# Altium schematic sheet S04_Microcontroller.SchDoc
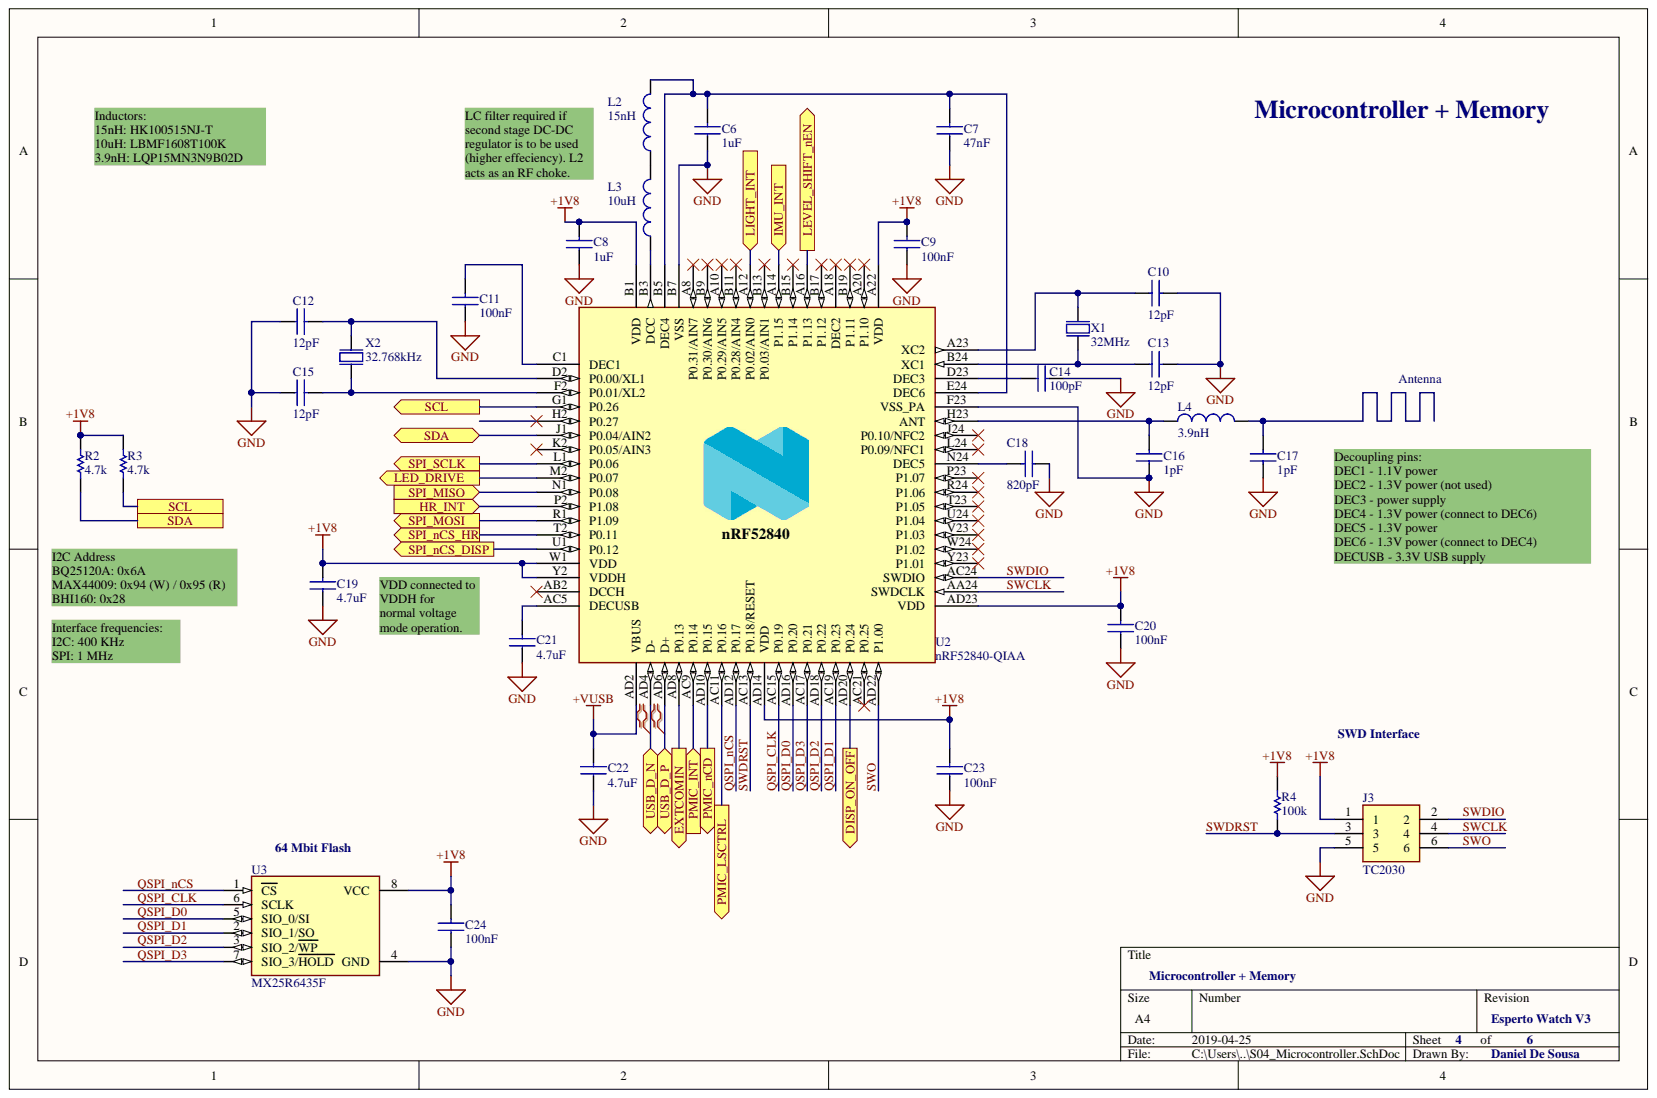
[diagram p0: S04_Microcontroller.SchDoc]

## Parts
C6  1uF  [CC_1005_0402_N]
C7  47nF  [CC_1005_0402_N]
C8  1uF  [CC_1005_0402_N]
C9  100nF  [CC_1005_0402_N]
C10  12pF  [CC_1005_0402_N]
C11  100nF  [CC_1005_0402_N]
C12  12pF  [CC_1005_0402_N]
C13  12pF  [CC_1005_0402_N]
C14  100pF  [CC_1005_0402_N]
C15  12pF  [CC_1005_0402_N]
C16  1pF  [CC_1005_0402_N]
C17  1pF  [CC_1005_0402_N]
C18  820pF  [CC_1005_0402_N]
C19  4.7uF  [CC_1005_0402_N]
C20  100nF  [CC_1005_0402_N]
C21  4.7uF  [CC_1005_0402_N]
C22  4.7uF  [CC_1005_0402_N]
C23  100nF  [CC_1005_0402_N]
C24  100nF  [CC_1005_0402_N]
J3  TC2030  [CONN_TC2030]  (no BOM)
L2  15nH  [RC_1005_0402_N]
L3  10uH  [IND_1608_0603_N]
L4  3.9nH  [RC_1005_0402_N]
R2  4.7k  [RC_1005_0402_N]
R3  4.7k  [RC_1005_0402_N]
R4  100k  [RC_1005_0402_N]
U2  nRF52840-QIAA  [nRF52840]
U3  MX25R6435F  [MX25R6435FZNIL0]
X1  32MHz  [XTAL_32M]
X2  32.768kHz  [XTAL_32K768]

## Ports
DISP_ON_OFF, EXTCOMIN, HR_INT, IMU_INT, LED_DRIVE, LEVEL_SHIFT_nEN, LIGHT_INT, PMIC_INT, PMIC_LSCTRL, PMIC_nCD, SCL, SDA, SPI_MISO, SPI_MOSI, SPI_nCS_DISP, SPI_nCS_HR, SPI_SCLK, USB_D_N, USB_D_P

## Nets
+1V8: C8.2 C9.2 C19.2 C20.2 C23.2 C24.2 J3.1 R2.2 R3.2 R4.2 U2.A22(VDD) U2.AD14(VDD) U2.AD23(VDD) U2.B1(VDD) U2.W1(VDD) U2.Y2(VDDH) U3.8(VCC)
+VUSB: C22.2 U2.AD2(VBUS)
GND: C6.1 C7.1 C8.1 C9.1 C10.2 C11.1 C12.1 C13.2 C14.2 C15.1 C16.1 C17.1 C18.1 C19.1 C20.1 C21.1 C22.1 C23.1 C24.1 J3.5 U2.74 U2.B7(VSS) U2.F23(VSS_PA) U3.4(GND) U3.9 X1.2 X1.4
DISP_ON_OFF: U2.AD20(P0.24) ; port DISP_ON_OFF
EXTCOMIN: U2.AD8(P0.13) ; port EXTCOMIN
HR_INT: U2.P2(P1.08) ; port HR_INT
IMU_INT: U2.A14(P1.15) ; port IMU_INT
LED_DRIVE: U2.M2(P0.07) ; port LED_DRIVE
LEVEL_SHIFT_nEN: U2.A16(P1.13) ; port LEVEL_SHIFT_nEN
LIGHT_INT: U2.A12(P0.02/AIN0) ; port LIGHT_INT
PMIC_INT: U2.AC9(P0.14) ; port PMIC_INT
PMIC_LSCTRL: U2.AC11(P0.16) ; port PMIC_LSCTRL
PMIC_nCD: U2.AD10(P0.15) ; port PMIC_nCD
QSPI_CLK: U2.AC15(P0.19) U3.6(SCLK)
QSPI_D0: U2.AD16(P0.20) U3.5(SIO_0/SI)
QSPI_D1: U2.AC19(P0.23) U3.2(SIO_1/SO)
QSPI_D2: U2.AD18(P0.22) U3.3(SIO_2/WP)
QSPI_D3: U2.AC17(P0.21) U3.7(SIO_3/HOLD)
QSPI_nCS: U2.AD12(P0.17) U3.1(CS)
SCL: R3.1 U2.G1(P0.26) ; port SCL
SDA: R2.1 U2.J1(P0.04/AIN2) ; port SDA
SPI_MISO: U2.N1(P0.08) ; port SPI_MISO
SPI_MOSI: U2.R1(P1.09) ; port SPI_MOSI
SPI_nCS_DISP: U2.U1(P0.12) ; port SPI_nCS_DISP
SPI_nCS_HR: U2.T2(P0.11) ; port SPI_nCS_HR
SPI_SCLK: U2.L1(P0.06) ; port SPI_SCLK
SWCLK: J3.4 U2.AA24(SWDCLK)
SWDIO: J3.2 U2.AC24(SWDIO)
SWDRST: J3.3 R4.1 U2.AC13(P0.18/RESET)
SWO: J3.6 U2.AD22(P1.00)
USB_D_N: U2.AD4(D-) ; port USB_D_N
USB_D_P: U2.AD6(D+) ; port USB_D_P
NetC6_2: C6.2 C7.2 L2.2 U2.B5(DEC4) U2.E24(DEC6)
NetC10_1: C10.1 U2.A23(XC2) X1.3
NetC11_2: C11.2 U2.C1(DEC1)
NetC12_2: C12.2 U2.D2(P0.00/XL1) X2.2
NetC13_1: C13.1 U2.B24(XC1) X1.1
NetC14_1: C14.1 U2.D23(DEC3)
NetC15_2: C15.2 U2.F2(P0.01/XL2) X2.1
NetC16_2: C16.2 L4.1 U2.H23(ANT)
NetC17_2: C17.2 L4.2
NetC18_2: C18.2 U2.N24(DEC5)
NetC21_2: C21.2 U2.AC5(DECUSB)
NetL2_1: L2.1 L3.2
NetL3_1: L3.1 U2.B3(DCC)

Not connected: U2 A8(P0.31/AIN7) A10(P0.29/AIN5) A18(DEC2) A20(P1.10) AB2(DCCH) AC21(P0.25) B9(P0.30/AIN6) B11(P0.28/AIN4) B13(P0.03/AIN1) B15(P1.14) B17(P1.12) B19(P1.11) H2(P0.27) J24(P0.10/NFC2) K2(P0.05/AIN3) L24(P0.09/NFC1) P23(P1.07) R24(P1.06) T23(P1.05) U24(P1.04) V23(P1.03) W24(P1.02) Y23(P1.01)

## Library data of the parts
C6-C24 = Capacitor: Component Kind: Standard; Description: Capacitor; Library Name: Esperto.SchLib
  C6 C8: VALUE: 1uF
  C7: VALUE: 47nF
  C9 C11 C20 C23 C24: VALUE: 100nF
  C10 C12 C13 C15: VALUE: 12pF
  C14: VALUE: 100pF
  C16 C17: VALUE: 1pF
  C18: VALUE: 820pF
  C19 C21 C22: VALUE: 4.7uF
L2 L4 = Inductor_0402: Inductor; Component Kind: Standard; Library Name: Esperto.SchLib
  L2: VALUE: 15nH
  L4: VALUE: 3.9nH
L3 = Inductor_0603: Inductor; Component Kind: Standard; VALUE: 10uH; Library Name: Esperto.SchLib
R2-R4 = Resistor: Component Kind: Standard; Description: Resistor; Library Name: Esperto.SchLib
  R2 R3: Value: 4.7k
  R4: Value: 100k
U2 = (no symbol name): Multi-protocol Bluetooth Low Energy, IEEE 802.15.4, ANT and 2.4GHz proprietary system-on-chip; Manufacturer: Nordic Semiconductor; Manufacturer Part Number: nRF52840-QIAAC0; Artikkelnr Noca: N.A.; Artikkelnr Simpro: N.A.; Component Kind: Standard; ComponentLink1Description: Datasheet; ComponentLink2Description: Supplier link; Footprint Doc: AQFN-73; LatestRevisionDate: 2017-12-06; Part Number: nRF52840-QIAAC0; Part number IPS: N.A.; Pin Count: 73; Published: 2017-08-21; Supplier: Nordic Semiconductor; Supplier part number: nRF52840-QIAAC0; Value: nRF52840-QIAA; Library Name: Esperto.SchLib; Publisher: Nordic Semiconductor
U3 = (no symbol name): Ultra low power, 64M-bit, serial multi I/O flash memory; Manufacturer: Macronix; Artikkelnr Noca: 15020015; Artikkelnr Simpro: N.A.; 2nd source part number: MX25R6435FZNIL0; 2nd source Supplier: Arrow; Component Kind: Standard; Datasheet: http://www.macronix.com/Lists/Datasheet/Attachments/3881/MX25R6435F,%20Wide%20Range,%2064Mb,%20v1.3.pdf; Footprint Doc: WSON-8; LatestRevisionDate: 2016-06-29; Manufacturer Part Number: MX25R6435FZNIL0; Part Number: MX25R6435FZNIL0; Pin Count: 8; Published: 2016-06-29; Supplier: Future; Supplier part number: MX25R6435FZNIL0; Value: MX25R6435F; Library Name: Esperto.SchLib; Publisher: Nordic Semiconductor
X1 = (no symbol name): XTAL SMD 2016, 32MHz, Cl=8pF, Tol: ±10ppm, Stab: ±10ppm; Manufacturer: Murata; Manufacturer Part Number: XRCGB32M000F1H01R0; Part Number: XTAL_SMD2016_32MHz_8pF_Murata; Part number IPS: 80000001424; Artikkelnr Noca: N.A.; Note: XTAL SMD 32.000MHz; Artikkelnr Simpro: N.A.; Component Kind: Standard; ComponentLink1Description: Datasheet; ComponentLink2Description: Supplier link; Footprint Doc: XTAL_2016; HelpURL: http://www.murata.com/en-global/products/productdetail.aspx?cate=cgsubCrystalUnits&partno=XRCGB32M000F1H01R0; LatestRevisionDate: 2017-11-09; Pin Count: 4; Published: 2016-10-26; Value: 32MHz; Library Name: Esperto.SchLib; Publisher: Nordic Semiconductor
X2 = (no symbol name): XTAL SMD 2012, 32.768kHz, 9pF, ±20ppm; Manufacturer: Seiko; Manufacturer Part Number: SC20S-9.0PF20PPM; Part Number: XTAL_SMD2012_32.768kHz_9pF_Seiko; Part number IPS: 80000001425; Artikkelnr Noca: N.A.; Note: XTAL SMD 32.768kHz; Artikkelnr Simpro: N.A.; Component Kind: Standard; ComponentLink1Description: Datasheet; ComponentLink2Description: Supplier link; Footprint Doc: XTAL_2012; HelpURL: http://www.sii.co.jp/en/quartz/files/2013/03/file_PRODUCT_MASTER_50811_GRAPHIC03.pdf; LatestRevisionDate: 2017-11-09; Pin Count: 2; Published: 2015-10-15; Value: 32.768kHz; Library Name: Esperto.SchLib; Publisher: Nordic Semiconductor
J3 = TC2030: Library Name: Esperto.SchLib
